# Altium schematic sheet AD936X_Power.SchDoc(AD936X_Power)
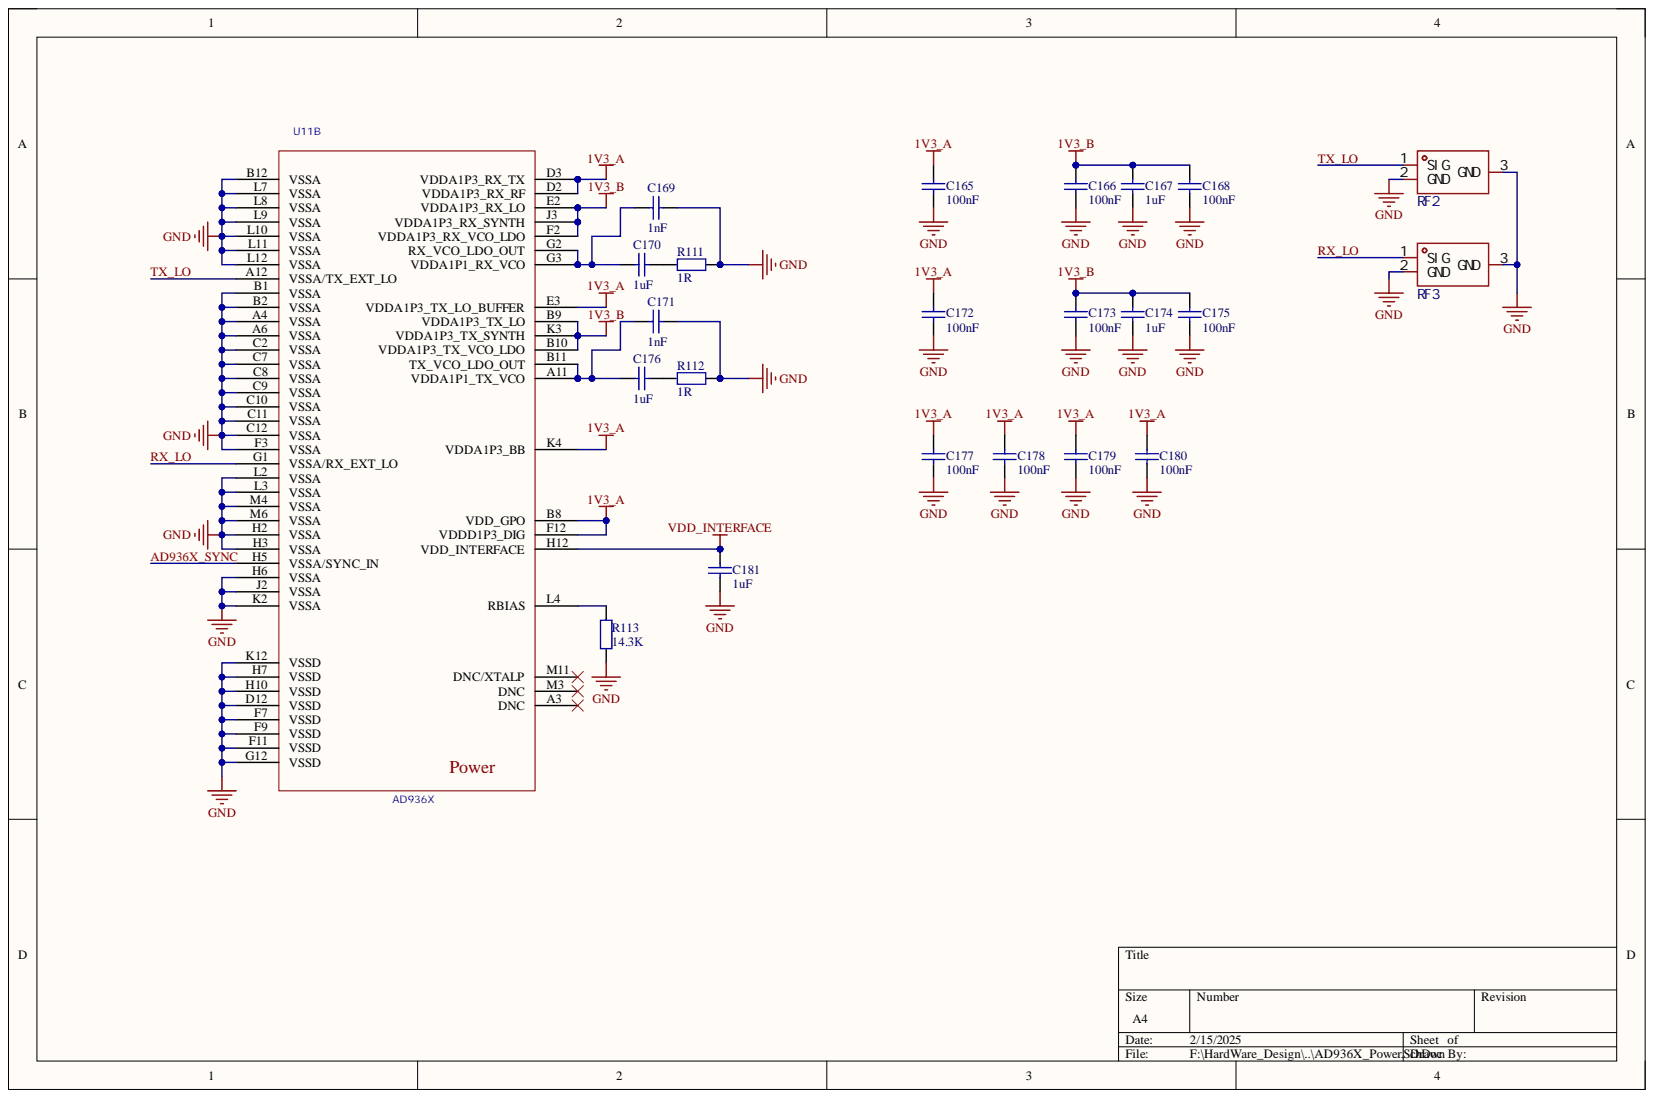
[diagram p0: AD936X_Power.SchDoc]

## Parts
C165  100nF  [C 0402_L]
C166  100nF  [C 0402_L]
C167  1uF  [C 0402_L]
C168  100nF  [C 0402_L]
C169  1nF  [C 0402_L]
C170  1uF  [C 0402_L]
C171  1nF  [C 0402_L]
C172  100nF  [C 0402_L]
C173  100nF  [C 0402_L]
C174  1uF  [C 0402_L]
C175  100nF  [C 0402_L]
C176  1uF  [C 0402_L]
C177  100nF  [C 0402_L]
C178  100nF  [C 0402_L]
C179  100nF  [C 0402_L]
C180  100nF  [C 0402_L]
C181  1uF  [C 0402_L]
R111  1R  [R 0402_L]
R112  1R  [R 0402_L]
R113  14.3K  [R 0402_L]
RF2  BWIPX-1-001E  [IPEX-SMD_BWIPX-1-001E]  (value not drawn)
RF3  BWIPX-1-001E  [IPEX-SMD_BWIPX-1-001E]  (value not drawn)
U11  AD936X  [BGA144C80P12X12_1000X1000X170]  (part B)

## Nets
1V3_A: C165.2 C172.2 C177.2 C178.2 C179.2 C180.2 U11.B8(VDD_GPO) U11.D2(VDDA1P3_RX_RF) U11.D3(VDDA1P3_RX_TX) U11.E3(VDDA1P3_TX_LO_BUFFER) U11.F12(VDDD1P3_DIG) U11.K4(VDDA1P3_BB)
1V3_B: C166.2 C167.2 C168.2 C173.2 C174.2 C175.2 U11.B9(VDDA1P3_TX_LO) U11.B10(VDDA1P3_TX_VCO_LDO) U11.E2(VDDA1P3_RX_LO) U11.F2(VDDA1P3_RX_VCO_LDO) U11.J3(VDDA1P3_RX_SYNTH) U11.K3(VDDA1P3_TX_SYNTH)
GND: C165.1 C166.1 C167.1 C168.1 C169.2 C171.2 C172.1 C173.1 C174.1 C175.1 C177.1 C178.1 C179.1 C180.1 C181.1 R111.2 R112.2 R113.1 RF2.2 RF2.3 RF3.2 RF3.3 U11.A4(VSSA) U11.A6(VSSA) U11.B1(VSSA) U11.B2(VSSA) U11.B12(VSSA) U11.C2(VSSA) U11.C7(VSSA) U11.C8(VSSA) U11.C9(VSSA) U11.C10(VSSA) U11.C11(VSSA) U11.C12(VSSA) U11.D12(VSSD) U11.F3(VSSA) U11.F7(VSSD) U11.F9(VSSD) U11.F11(VSSD) U11.G12(VSSD) U11.H2(VSSA) U11.H3(VSSA) U11.H6(VSSA) U11.H7(VSSD) U11.H10(VSSD) U11.J2(VSSA) U11.K2(VSSA) U11.K12(VSSD) U11.L2(VSSA) U11.L3(VSSA) U11.L7(VSSA) U11.L8(VSSA) U11.L9(VSSA) U11.L10(VSSA) U11.L11(VSSA) U11.L12(VSSA) U11.M4(VSSA) U11.M6(VSSA)
VDD_INTERFACE: C181.2 U11.H12(VDD_INTERFACE)
AD936X_SYNC: U11.H5(VSSA/SYNC_IN)
RX_LO: RF3.1 U11.G1(VSSA/RX_EXT_LO)
TX_LO: RF2.1 U11.A12(VSSA/TX_EXT_LO)
NetC169_1: C169.1 C170.1 U11.G2(RX_VCO_LDO_OUT) U11.G3(VDDA1P1_RX_VCO)
NetC170_2: C170.2 R111.1
NetC171_1: C171.1 C176.1 U11.A11(VDDA1P1_TX_VCO) U11.B11(TX_VCO_LDO_OUT)
NetC176_2: C176.2 R112.1
NetR113_2: R113.2 U11.L4(RBIAS)

Not connected: U11 A3(DNC) M3(DNC) M11(DNC/XTALP)

## Library data of the parts
C165-C181 = Cap: 贴片电容; Component Kind: Standard; Library Name: A_Schlib.SchLib
  C165 C166 C168 C172 C173 C175 C177-C180: value: 100nF
  C167 C170 C174 C176 C181: value: 1uF
  C169 C171: value: 1nF
R111-R113 = Res: 通用; Component Kind: Standard; Library Name: A_Schlib.SchLib
  R111 R112: value: 1R
  R113: value: 14.3K
RF2 RF3 = BWIPX-1-001E: 连接器类型:板端;接口类型:内针;端口数量:1;接口直径:-;接口长度/高度:-;频率-最大值:6GHz;射频系列:IPEX;阻抗:50Ω;样式:-;工作温度范围:-;; Manufacturer: BAT WIRELESS(蝙蝠无线); Add into BOM: yes; Connector Type: 板端; Frequency-Max: 6GHz; Impedance: 50Ω; Interface Type: 内针; Number of Ports: 1; RF Series: IPEX; Component Kind: Standard; Convert to PCB: yes; Datasheet: https://atta.szlcsc.com/upload/public/pdf/source/20201223/C496552_05E5A9956EAE1A8819C5051D085B1DED.pdf; Device: BWIPX-1-001E; Interface Diameter: -; Interface Length/Height: -; JLCPCB Part Class: 扩展库; LCSC Part Name: IPEX 内针; Library Name: A_Schlib.SchLib; Manufacturer Part: BWIPX-1-001E; Operating Temperature Range: -; Style: -; Supplier: LCSC; Supplier Footprint: SMD; Supplier Part: C496552; Symbol: BWIPX-1-001E
U11 = AD936X: 射频捷变收发器; Component Kind: Standard; Library Name: A_Schlib.SchLib
